# Altium schematic sheet Sheet2.SchDoc(Sheet2)
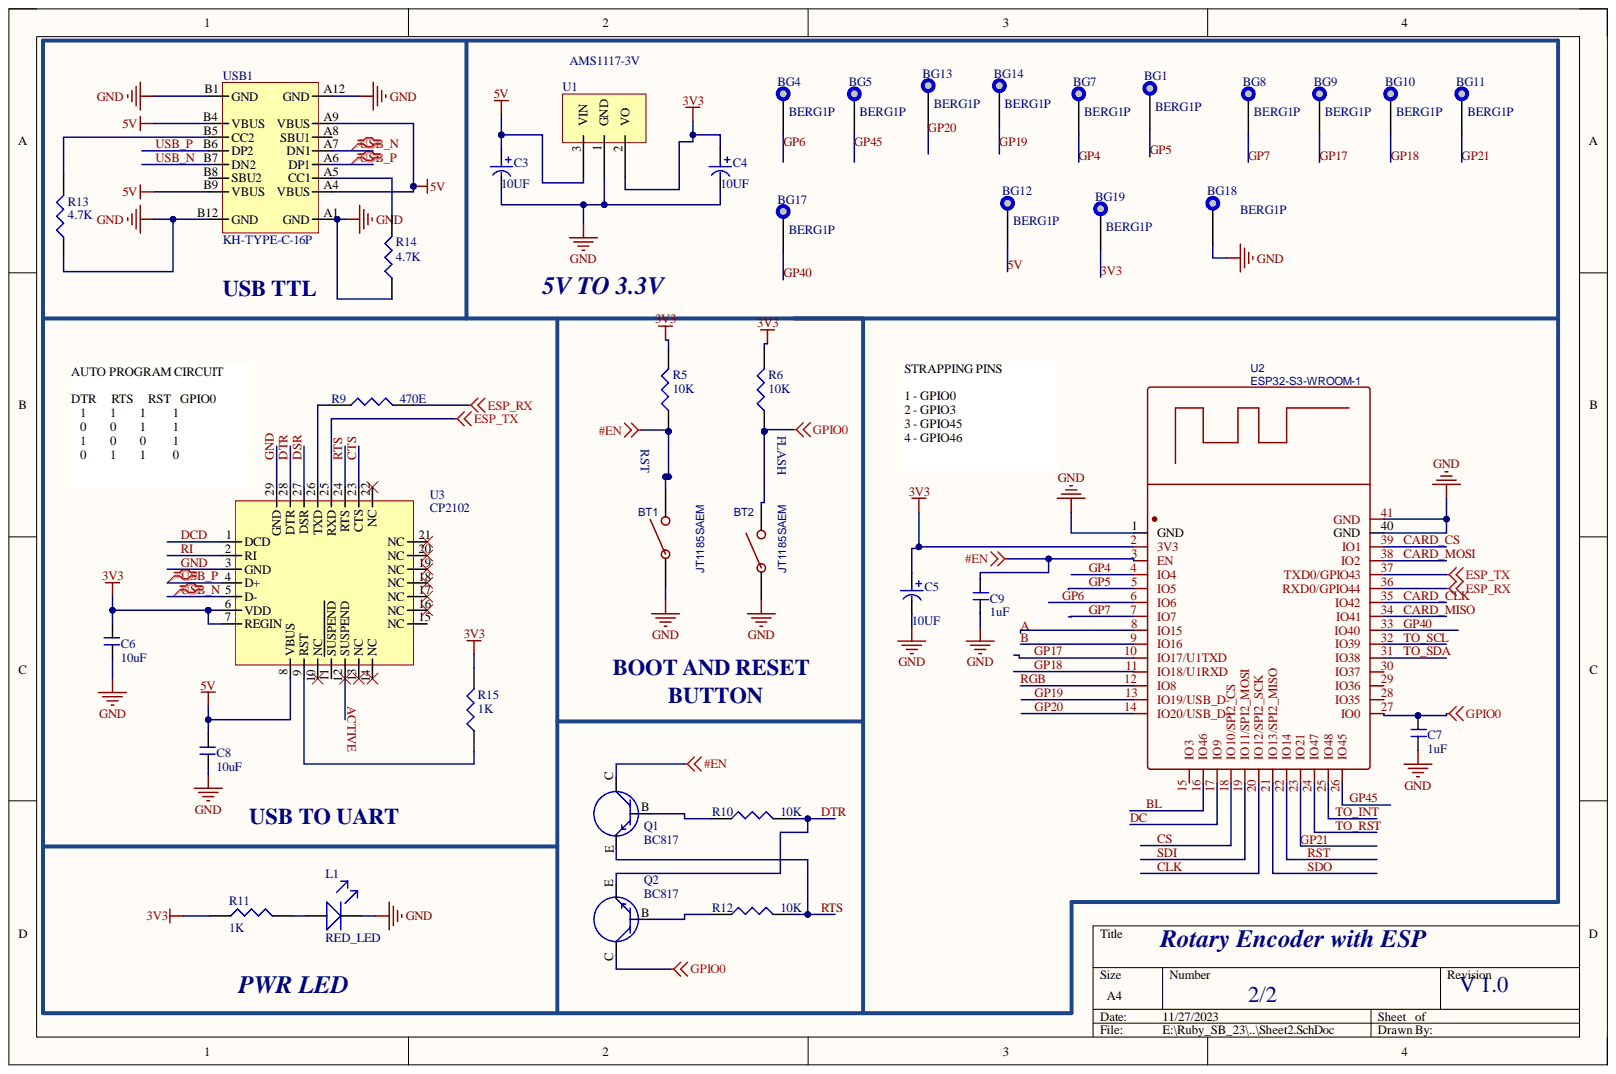
[diagram p0: Sheet2.SchDoc]

## Parts
BG1  BERG1P  [CLIP_]
BG4  BERG1P  [CLIP_]
BG5  BERG1P  [CLIP_]
BG7  BERG1P  [CLIP_]
BG8  BERG1P  [CLIP_]
BG9  BERG1P  [CLIP_]
BG10  BERG1P  [CLIP_]
BG11  BERG1P  [CLIP_]
BG12  BERG1P  [CLIP_]
BG13  BERG1P  [CLIP_]
BG14  BERG1P  [CLIP_]
BG17  BERG1P  [CLIP_]
BG18  BERG1P  [CLIP_]
BG19  BERG1P  [CLIP_]
BT1  JT1185SAEM  [SW_SMD_L3.9_W2.9_P4.15]
BT2  JT1185SAEM  [SW_SMD_L3.9_W2.9_P4.15]
C3  10UF  [tantlum caseA]
C4  10UF  [tantlum caseA]
C5  10UF  [C_0603]
C6  10uF  [C_0603]
C7  1uF  [C_0603]
C8  10uF  [C_0603]
C9  1uF  [C_0603]
L1  RED_LED  [LED_0603]
Q1  BC817  [SOT23]
Q2  BC817  [SOT23]
R5  10K  [R_0603]
R6  10K  [R_0603]
R9  470E  [R_0603]
R10  10K  [R_0603]
R11  1K  [R_0603]
R12  10K  [R_0603]
R13  4.7K  [R_0603]
R14  4.7K  [R_0603]
R15  1K  [R_0603]
U1  AMS1117-3V  [AMS1117_3V3]
U2  ESP32-S3-WROOM-1  [ESP32-S3 WROOM1]
U3  CP2102  [CP2102]
USB1  KH-TYPE-C-16P  [USBC-31-M-12]

## Nets
#EN: BT1.2 C9.2 Q1.C R5.1 U2.3(EN)
ESP_RX: R9.2 U2.36(RXD0/GPIO44)
ESP_TX: U2.37(TXD0/GPIO43) U3.25(RXD)
GPIO0: BT2.2 C7.2 Q2.C R6.1 U2.27(IO0)
3V3: BG19.1 C4.1 C5.1 C6.1 R5.2 R6.2 R11.1 R15.2 U1.2 U2.2(3V3) U3.6(VDD) U3.7(REGIN)
5V: BG12.1 C3.1 C8.1 U1.3 U3.8(VBUS) USB1.A4(VBUS) USB1.A9(VBUS) USB1.B4(VBUS) USB1.B9(VBUS)
A: U2.8(IO15)
ACTIVE: U3.12(SUSPEND)
B: U2.9(IO16)
BL: U2.16(IO46)
CARD_CLK: U2.35(IO42)
CARD_CS: U2.39(IO1)
CARD_MISO: U2.34(IO41)
CARD_MOSI: U2.38(IO2)
CLK: U2.20(IO12/SPI2_SCK)
CS: U2.18(IO10/SPI2_CS)
CTS: U3.23(CTS)
DC: U2.17(IO9)
DCD: U3.1(DCD)
DSR: U3.27(DSR)
DTR: Q2.E R10.2 U3.28(DTR)
GND: BG18.1 BT1.1 BT2.1 C3.2 C4.2 C5.2 C6.2 C7.1 C8.2 C9.1 L1.K R13.1 R14.1 U1.1 U2.1(GND) U2.40(GND) U2.41(GND) U3.3(GND) U3.29(GND) USB1.A1(GND) USB1.A12(GND) USB1.B1(GND) USB1.B12(GND)
GP4: BG7.1 U2.4(IO4)
GP5: BG1.1 U2.5(IO5)
GP6: BG4.1 U2.6(IO6)
GP7: BG8.1 U2.7(IO7)
GP17: BG9.1 U2.10(IO17/U1TXD)
GP18: BG10.1 U2.11(IO18/U1RXD)
GP19: BG14.1 U2.13(IO19/USB_D-)
GP20: BG13.1 U2.14(IO20/USB_D+)
GP21: BG11.1 U2.23(IO21)
GP40: BG17.1 U2.33(IO40)
GP45: BG5.1 U2.26(IO45)
RGB: U2.12(IO8)
RI: U3.2(RI)
RST: U2.22(IO14)
RTS: Q1.E R12.2 U3.24(RTS)
SDI: U2.19(IO11/SPI2_MOSI)
SDO: U2.21(IO13/SPI2_MISO)
TO_INT: U2.25(IO48)
TO_RST: U2.24(IO47)
TO_SCL: U2.32(IO39)
TO_SDA: U2.31(IO38)
USB_N: U3.5(D-) USB1.A7(DN1) USB1.B7(DN2)
USB_P: U3.4(D+) USB1.A6(DP1) USB1.B6(DP2)
NetL1_A: L1.A R11.2
NetQ1_B: Q1.B R10.1
NetQ2_B: Q2.B R12.1
NetR9_1: R9.1 U3.26(TXD)
NetR13_2: R13.2 USB1.B5(CC2)
NetR14_2: R14.2 USB1.A5(CC1)
NetR15_1: R15.1 U3.9(RST)

Not connected: U2 15(IO3) 28(IO35) 29(IO36) 30(IO37); U3 10(NC) 11(SUSPEND) 13(NC) 14(NC) 15(NC) 16(NC) 17(NC) 18(NC) 19(NC) 20(NC) 21(NC) 22(NC); USB1 A8(SBU1) B8(SBU2)

## Library data of the parts
BG1 BG4 BG5 BG7-BG14 BG17-BG19 = TESTPOINT: Component Kind: Standard; Library Name: MIX.SchLib
BT1 BT2 = JT1185SAEM: BOM_Manufacturer: NAMAE(韩国南涯); Contributor: LCSC; BOM_JLCPCB Part Class: Extended Part; BOM_Manufacturer Part: JT1185SAEM; BOM_Supplier: LCSC; BOM_Supplier Part: C465068; Component Kind: Standard; spicePre: S; spiceSymbolName: JT1185SAEM
C3-C5 = CAP_ELE: Component Kind: Standard; Library Name: MIX.SchLib
C6-C9 = CAP_NONPOLAR: Component Kind: Standard; Library Name: dxp_pasl_lib.IntLib
L1 = LED: Component Kind: Standard; Library Name: dxp_pasl_lib.IntLib
Q1 Q2 = TRANSISTER_NPN: Component Kind: Standard; Library Name: dxp_pasl_lib.IntLib
R5 R6 R9-R15 = RES: Component Kind: Standard; Library Name: dxp_pasl_lib.IntLib
U1 = AMS1117: Component Kind: Standard; Library Name: dxp_pasl_lib.IntLib
U2 = ESP32-S3-WROOM-1(N16): BOM_Manufacturer: ESPRESSIF(乐鑫); BOM_Manufacturer Part: ESP32-S3-WROOM-1-N16; Contributor: lcsc; BOM_JLCPCB Part Class: Extended Part; BOM_Supplier: LCSC; BOM_Supplier Part: C2913199; Component Kind: Standard; link: https://atta.szlcsc.com/upload/public/pdf/source/20220628/A2AC12B3C87735CAEB7EBE2DBE80936C.pdf; spicePre: U; spiceSymbolName: ESP32-S3-WROOM-1(N16)
U3 = CP2102_1: QFN, 28-Leads, Body 5.0x5.0mm (max), Pitch 0.50mm, IPC Medium Density; Component Kind: Standard; Library Name: dxp_pasl_lib.IntLib
USB1 = USB TYPE-C KH-TYPE-C-16P: 3A 1 Surface Mount 16 Female -40?~+85? Type-C SMD USB Connectors ROHS #  C709357; Component Kind: Standard; ComponentLink2Description: New link; ComponentLink2URL: https://lcsc.com/product-detail/USB-Connectors_Shenzhen-Kinghelm-Elec-KH-TYPE-C-16P_C709357.html; Supplier 1: LCSC; Supplier Part Number 1: C165948; Library Name: dxp_pasl_lib.IntLib
